# Altium schematic sheet ADC_Filter.SchDoc
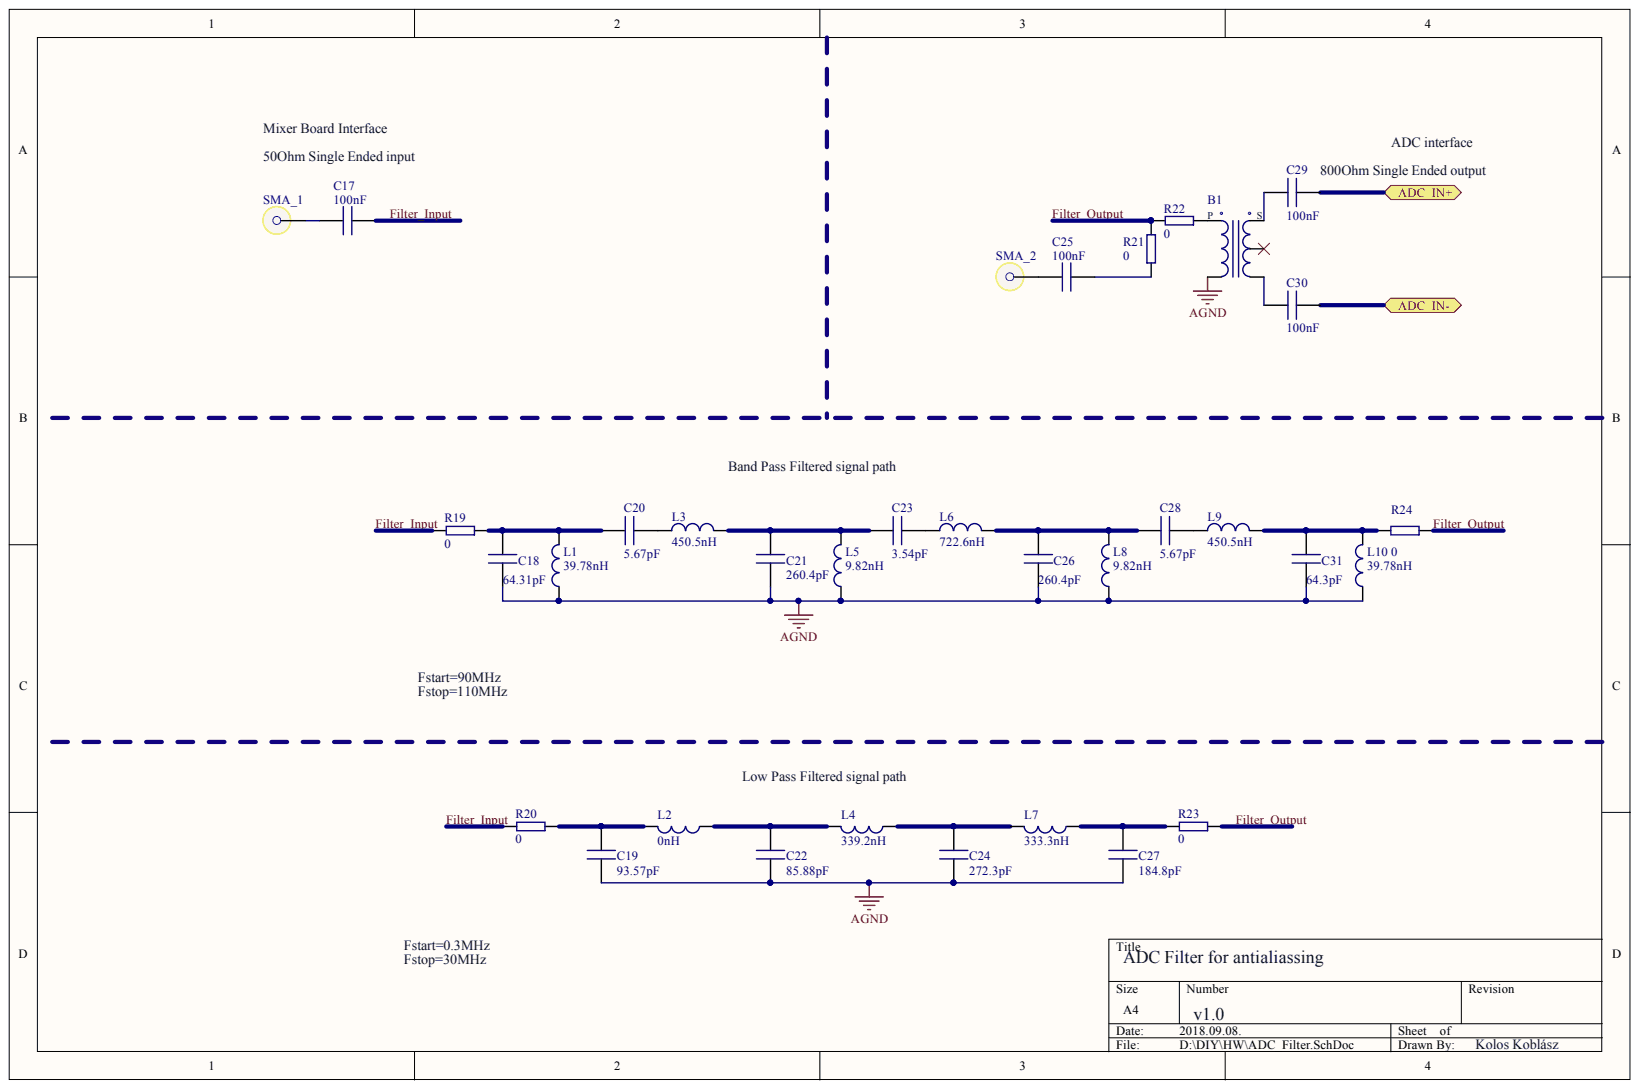
[diagram p0: ADC_Filter.SchDoc]

## Parts
B1  Balun  [SMD]  (value not drawn)
C17  value 100nF  (hidden comment: Cap1)
C18  value 64.31pF  (hidden comment: Cap1)
C19  value 93.57pF  (hidden comment: Cap1)
C20  value 5.67pF  (hidden comment: Cap1)
C21  value 260.4pF  (hidden comment: Cap1)
C22  value 85.88pF  (hidden comment: Cap1)
C23  value 3.54pF  (hidden comment: Cap1)
C24  value 272.3pF  (hidden comment: Cap1)
C25  value 100nF  (hidden comment: Cap1)
C26  value 260.4pF  (hidden comment: Cap1)
C27  value 184.8pF  (hidden comment: Cap1)
C28  value 5.67pF  (hidden comment: Cap1)
C29  value 100nF  (hidden comment: Cap1)
C30  value 100nF  (hidden comment: Cap1)
C31  value 64.3pF  (hidden comment: Cap1)
L1  value 39.78nH  [SMD]  (hidden comment: Inductor)
L2  value 0nH  [SMD]  (hidden comment: Inductor)
L3  value 450.5nH  [SMD]  (hidden comment: Inductor)
L4  value 339.2nH  [SMD]  (hidden comment: Inductor)
L5  value 9.82nH  [SMD]  (hidden comment: Inductor)
L6  value 722.6nH  [SMD]  (hidden comment: Inductor)
L7  value 333.3nH  [SMD]  (hidden comment: Inductor)
L8  value 9.82nH  [SMD]  (hidden comment: Inductor)
L9  value 450.5nH  [SMD]  (hidden comment: Inductor)
L10  value 39.78nH  [SMD]  (hidden comment: Inductor)
R19  value 0  [SMD]  (hidden comment: Resistor)
R20  value 0  [SMD]  (hidden comment: Resistor)
R21  value 0  [SMD]  (hidden comment: Resistor)
R22  value 0  [SMD]  (hidden comment: Resistor)
R23  value 0  [SMD]  (hidden comment: Resistor)
R24  Resistor  [SMD]  (value not drawn)
SMA_1  SMA1
SMA_2  SMA1  (value not drawn)

## Ports
ADC_IN+, ADC_IN-

## Nets
AGND: B1.4 C18.2 C19.1 C21.2 C22.1 C24.1 C26.2 C27.1 C31.2 L1.1 L5.1 L8.1 L10.1
FILTER_INPUT: C17.1 R19.2 R20.2
FILTER_OUTPUT: R21.2 R22.2 R23.1 R24.1
NetB1_1: B1.1 C29.2
NetB1_3: B1.3 C30.2
NetB1_5: B1.5 R22.1
NetC17_2: C17.2 SMA_1.1
NetC18_1: C18.1 C20.1 L1.2 R19.1
NetC19_2: C19.2 L2.2 R20.1
NetC20_2: C20.2 L3.1
NetC21_1: C21.1 C23.1 L3.2 L5.2
NetC22_2: C22.2 L2.1 L4.2
NetC23_2: C23.2 L6.1
NetC24_2: C24.2 L4.1 L7.2
NetC25_1: C25.1 R21.1
NetC25_2: C25.2 SMA_2.1
NetC26_1: C26.1 C28.1 L6.2 L8.2
NetC27_2: C27.2 L7.1 R23.2
NetC28_2: C28.2 L9.1
NetC29_1: C29.1
NetC30_1: C30.1
NetC31_1: C31.1 L9.2 L10.2 R24.2

Not connected: B1 2

## Library data of the parts
B1 = Balun1: SMD Balun; ImpedanceTransformation P: 2:1; MaxFrequency: 500MHz; MinFrequency: 5MHz; Component Kind: Standard; Library Name: RadioProjectSchLib.SchLib
C17-C31 = Cap1: SMD Capacitor; MaxVolt: 16V; Precision: 1%; Component Kind: Standard; Library Name: RadioProjectSchLib.SchLib
L1-L10 = Ind1: SMD Inductor; MaxCurent: 100mA; Precision: 10%; Component Kind: Standard; Library Name: RadioProjectSchLib.SchLib
R19-R23 = Res1: SMD Resistor; MaxPower: 0.1W; Precision: 1%; Component Kind: Standard; Library Name: RadioProjectSchLib.SchLib
R24 = Res1: SMD Resistor; MaxPower: 0.1W; Precision: 1%; Value: 0; Component Kind: Standard; Library Name: RadioProjectSchLib.SchLib
SMA_1 SMA_2 = SMA1: SMA Female; Shape: 90°; Component Kind: Standard; Library Name: RadioProjectSchLib.SchLib; Mounting: TroughHole
